# Altium schematic sheet Linefollower_main_board.SchDoc
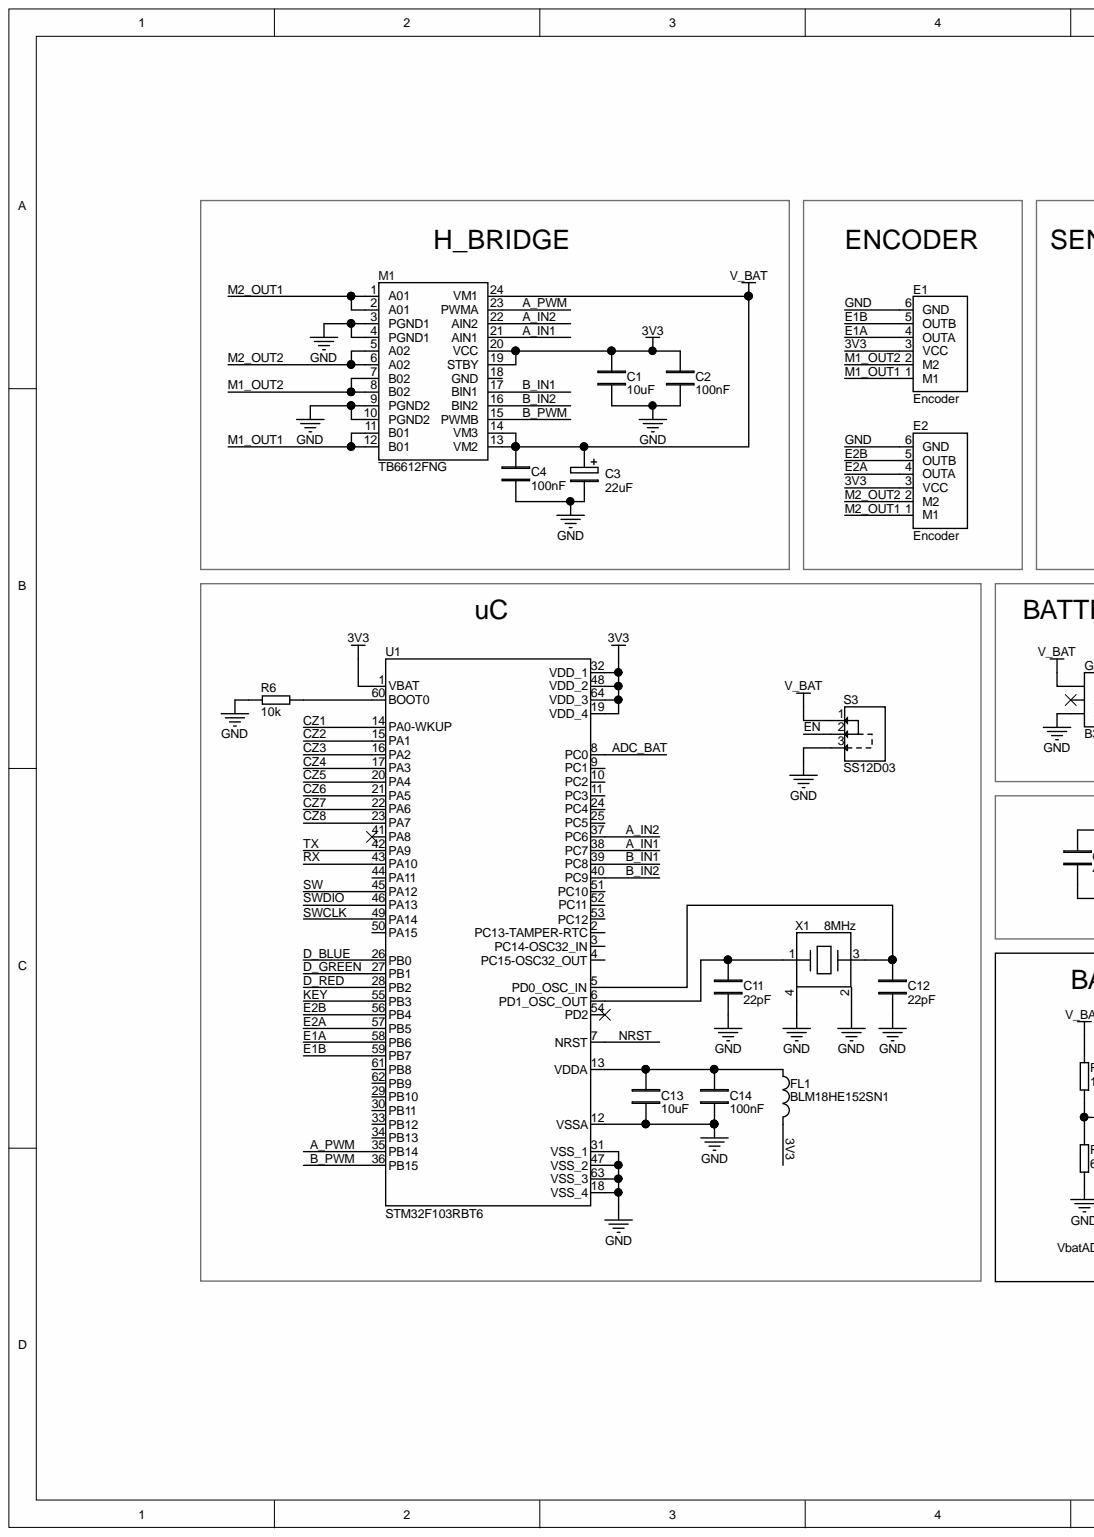
[diagram p0: Linefollower_main_board.SchDoc, left]
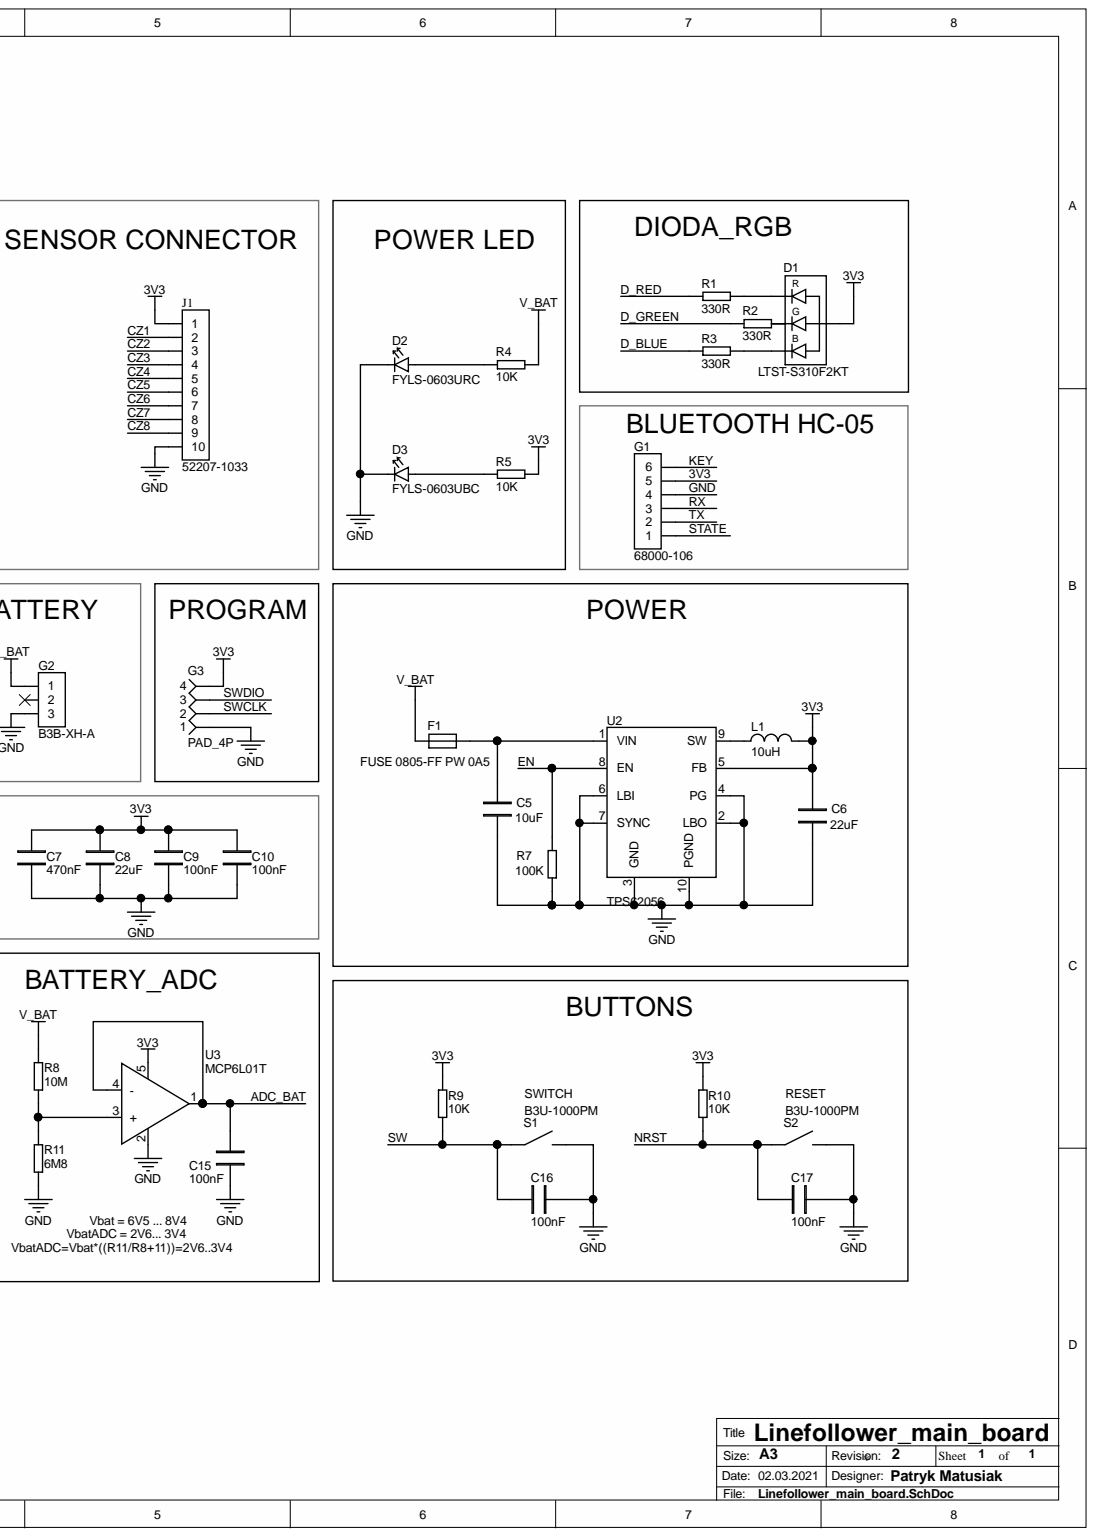
[diagram p1: Linefollower_main_board.SchDoc, right]

## Parts
C1  value 10uF  [CAP0603]  (hidden comment: 10uF  0603)
C2  value 100nF  [CAP0603]  (hidden comment: 100nF  0603)
C3  value 22uF  [SMD_A]  (hidden comment: 22uF  SMD_A)
C4  value 100nF  [CAP0603]  (hidden comment: 100nF  0603)
C5  value 10uF  [CAP1206]  (hidden comment: 10uF  1206)
C6  value 22uF  [CAP1206]  (hidden comment: 22uF  1206)
C7  value 470nF  [CAP0603]  (hidden comment: 470nF  0603)
C8  value 22uF  [CAP1206]  (hidden comment: 22uF  1206)
C9  value 100nF  [CAP0603]  (hidden comment: 100nF  0603)
C10  value 100nF  [CAP0603]  (hidden comment: 100nF  0603)
C11  value 22pF  [CAP0402]  (hidden comment: 22pF  0402)
C12  value 22pF  [CAP0402]  (hidden comment: 22pF  0402)
C13  value 10uF  [CAP0603]  (hidden comment: 10uF  0603)
C14  value 100nF  [CAP0603]  (hidden comment: 100nF  0603)
C15  value 100nF  [CAP0603]  (hidden comment: 100nF  0603)
C16  value 100nF  [CAP0603]  (hidden comment: 100nF  0603)
C17  value 100nF  [CAP0603]  (hidden comment: 100nF  0603)
D1  Part_number: LTST-S310F2KT  [SMD_4_Diode_RGB_LTST]  (hidden comment: SMD-4    LTST-S310F2KT)
D2  Part_number: FYLS-0603URC  [DIODE0603_RED]  (hidden comment: 0603  FYLS-0603URC)
D3  Part_number: FYLS-0603UBC  [DIODE0603_BLUE]  (hidden comment: 0603  FYLS-0603UBC)
E1  Individual  Encoder  [Magnetic Encoder with Motor]  (value not drawn)
E2  Individual  Encoder  [Magnetic Encoder with Motor]  (value not drawn)
F1  500mA  0805  FUSE 0805-FF PW 0A5  [FUSE0805]  (value not drawn)
FL1  Part_number: BLM18HE152SN1  [Inductor0603]  (hidden comment: 0603  BLM18HE152SN1)
G1  Part_number: 68000-106  [GOLDPIN_6P_2.54MM]  (hidden comment: THT  68000-106)
G2  B3B-XH-A  [B3B_XH_A]
G3  PAD_4P  [PAD_4P_1.27mm]
J1  Part_number: 52207-1033  [MOLEX FFC 1mm 10 PIN]  (hidden comment: PIN10, SMD, 1mm  52207-1033)
L1  value 10uH  [7.3MMX7.3MMX4.5MM]  (hidden comment: 10uH  7.3x7.3x3.5mm  SRR0735A-100M)
M1  SSOP24  TB6612FNG  [SSOP24]  (value not drawn)
R1  value 330R  [Res0603]  (hidden comment: 330R  0603)
R2  value 330R  [Res0603]  (hidden comment: 330R  0603)
R3  value 330R  [Res0603]  (hidden comment: 330R  0603)
R4  value 10K  [Res0603]  (hidden comment: 10K  0603)
R5  value 10K  [Res0603]  (hidden comment: 10K  0603)
R6  value 10k  [Res0603]  (hidden comment: 10k  0603)
R7  value 100K  [Res0603]  (hidden comment: 100K  0603)
R8  value 10M  [Res0603]  (hidden comment: 10M  0603)
R9  value 10K  [Res0603]  (hidden comment: 10K  0603)
R10  value 10K  [Res0603]  (hidden comment: 10K  0603)
R11  value 6M8  [Res0603]  (hidden comment: 6M8  0603)
S1  B3U-1000PM  [B3U-1000PM]
S2  B3U-1000PM  [B3U-1000PM]
S3  SS12D03  [SS12D03]
U1  LQFP (64) 10MMX10MM  STM32F103RBT6  [LQFP (64)  10MMx10MM]  (value not drawn)
U2  MSOP-10  TPS62056  [MSOP-10]  (value not drawn)
U3  SC-70-5  MCP6L01T  [SC-70-5]  (value not drawn)
X1  value 8MHz  [SMD 5mm x 3.2mm]  (hidden comment: 8MHz  SMD, 5mm x 3.2mm  ABM3B-8.000MHZ-B2-T)

## Nets
V_BAT: C3.1 C4.2 F1.1 G2.1 M1.13(VM2) M1.14(VM3) M1.24(VM1) R4.2 R8.2 S3.1
3V3: C1.2 C2.2 C6.2 C7.1 C8.1 C9.1 C10.1 D1.4 E1.3(VCC) E2.3(VCC) FL1.2 G1.5 G3.4 J1.1 L1.2 M1.19(STBY) M1.20(VCC) R5.2 R9.1 R10.1 U1.1(VBAT) U1.19(VDD_4) U1.32(VDD_1) U1.48(VDD_2) U1.64(VDD_3) U2.5(FB) U3.5
A_IN1: M1.21(AIN1) U1.38(PC7)
A_IN2: M1.22(AIN2) U1.37(PC6)
A_PWM: M1.23(PWMA) U1.35(PB14)
ADC_BAT: C15.2 U1.8(PC0) U3.1 U3.4(-)
B_IN1: M1.17(BIN1) U1.39(PC8)
B_IN2: M1.16(BIN2) U1.40(PC9)
B_PWM: M1.15(PWMB) U1.36(PB15)
CZ1: J1.2 U1.14(PA0-WKUP)
CZ2: J1.3 U1.15(PA1)
CZ3: J1.4 U1.16(PA2)
CZ4: J1.5 U1.17(PA3)
CZ5: J1.6 U1.20(PA4)
CZ6: J1.7 U1.21(PA5)
CZ7: J1.8 U1.22(PA6)
CZ8: J1.9 U1.23(PA7)
D_BLUE: R3.1 U1.26(PB0)
D_GREEN: R2.1 U1.27(PB1)
D_RED: R1.1 U1.28(PB2)
E1A: E1.4(OUTA) U1.58(PB6)
E1B: E1.5(OUTB) U1.59(PB7)
E2A: E2.4(OUTA) U1.57(PB5)
E2B: E2.5(OUTB) U1.56(PB4)
EN: R7.2 S3.2 U2.8(EN)
GND: C1.1 C2.1 C3.2 C4.1 C5.1 C6.1 C7.2 C8.2 C9.2 C10.2 C11.1 C12.1 C13.1 C14.1 C15.1 C16.2 C17.2 D2.1 D3.1 E1.6(GND) E2.6(GND) G1.4 G2.3 G3.1 J1.10 M1.3(PGND1) M1.4(PGND1) M1.9(PGND2) M1.10(PGND2) R6.1 R7.1 R11.1 S1.1 S2.1 S3.3 U1.12(VSSA) U1.18(VSS_4) U1.31(VSS_1) U1.47(VSS_2) U1.63(VSS_3) U2.2(LBO) U2.3(GND) U2.4(PG) U2.6(LBI) U2.7(SYNC) U2.10(PGND) U3.2 X1.2 X1.4
KEY: G1.6 U1.55(PB3)
M1_OUT1: E1.1(M1) M1.11(B01) M1.12(B01)
M1_OUT2: E1.2(M2) M1.7(B02) M1.8(B02)
M2_OUT1: E2.1(M1) M1.1(A01) M1.2(A01)
M2_OUT2: E2.2(M2) M1.5(A02) M1.6(A02)
NRST: C17.1 R10.2 S2.2 U1.7(NRST)
RX: G1.3 U1.43(PA10)
STATE: G1.1
SW: C16.1 R9.2 S1.2 U1.45(PA12)
SWCLK: G3.2 U1.49(PA14)
SWDIO: G3.3 U1.46(PA13)
TX: G1.2 U1.42(PA9)
NetC5_2: C5.2 F1.2 U2.1(VIN)
NetC11_2: C11.2 U1.6(PD1_OSC_OUT) X1.1
NetC12_2: C12.2 U1.5(PD0_OSC_IN) X1.3
NetC13_2: C13.2 C14.2 FL1.1 U1.13(VDDA)
NetD1_1: D1.1 R1.2
NetD1_2: D1.2 R2.2
NetD1_3: D1.3 R3.2
NetD2_2: D2.2 R4.1
NetD3_2: D3.2 R5.1
NetL1_1: L1.1 U2.9(SW)
NetR6_2: R6.2 U1.60(BOOT0)
NetR8_1: R8.1 R11.2 U3.3(+)

Not connected: G2 2; M1 18(GND); U1 2(PC13-TAMPER-RTC) 3(PC14-OSC32_IN) 4(PC15-OSC32_OUT) 9(PC1) 10(PC2) 11(PC3) 24(PC4) 25(PC5) 29(PB10) 30(PB11) 33(PB12) 34(PB13) 41(PA8) 44(PA11) 50(PA15) 51(PC10) 52(PC11) 53(PC12) 54(PD2) 61(PB8) 62(PB9)

## Library data of the parts
C1 C2 C4 C7-C14 = Capacitor: Component Kind: Standard; Description: Capacitor; Library Name: Library.IntLib
  C1 C2 C4 C7 C9 C10 C13 C14: Package: 0603
  C8: Package: 1206
  C11 C12: Package: 0402
C3 = Capacitor_Polarity: Component Kind: Standard; Description: Capacitor_Polarity; Library Name: Library.IntLib; Package: SMD_A
C5 C6 = Capacitor: Tolerance: +/-10%; Voltage: 10V; Component Kind: Standard; Description: Capacitor; Library Name: Library.IntLib; Package: 1206
C15 = Capacitor: Tolerance: 5%; Voltage: 16V; Component Kind: Standard; Description: Capacitor; Library Name: Library.IntLib; Package: 0603
C16 C17 = Capacitor: Tolerance: +/-10%; Voltage: 16V; Component Kind: Standard; Description: Capacitor; Library Name: Library.IntLib; Package: 0603
D1 = Diode_LTST-S310F2KT: Diode RGB, SMD LED Side Look Red/Green/Blue; Component Kind: Standard; Library Name: Library.IntLib; Value: SMD-4
D2 = DiodeLED_0603_red: LED; SMD; 0603; red 80mcd; 1,6x0,8x0,8mm; 130°; 2,1÷2.6V; Manufacturer: Foryard; Component Kind: Standard; Library Name: Diode.SchLib; Package: 0603
D3 = DiodeLED_0603_blue: LED; SMD; 0603; blue 120mcd; 1,6x0,8x0,8mm; 130°; 2,8÷3,6V; Manufacturer: Foryard; Component Kind: Standard; Library Name: Diode.SchLib; Package: 0603
E1 E2 = Encoder: Magnetic Encoder Pair Kit for Micro Metal Gearmotors, 12 CPR, 2.7-18V; Manufacturer: Pololu; Component Kind: Standard; Library Name: Device.SchLib; Package: Individual; Part_number: Encoder
F1 = FUSE 0805-FF PW 0A5: FUSE, 500mA, 32V, 0805; Component Kind: Standard; Library Name: Library.IntLib; Package: 0805; Part_number: FUSE 0805-FF PW 0A5; Value: 500mA
FL1 = Inductor: Ferrite Beads; Component Kind: Standard; Library Name: Library.IntLib; Package: 0603
G1 = GOLDPIN_6P: Goldpin 2,54mm 6PIN; Component Kind: Standard; Library Name: Library.IntLib; Package: THT
G2 = B3B_XH_A: JST 2,5mm, XH, 3PIN, THT, 250V, 3A; Manufacturer: JST Japanese Solderless Terminals; Component Kind: Standard; Library Name: Library.IntLib; Part_number: B3B-XH-A
G3 = PAD_4P: PAD Hole 0.6mm Radius 0.5mm; Component Kind: Standard; Library Name: Library.IntLib; Part_number: PAD_4P
J1 = Molex FFC 1mm 10 PIN: Connector Molex FFC 1mm 10 PIN; Component Kind: Standard; Library Name: Connector.SchLib; Manufacturer: Molex; Package: PIN10, SMD, 1mm
L1 = Inductor 10uH 2.1A: Inductor 10uH 20% 2.1A SMD 0735 AEC-Q200; Component Kind: Standard; Current: 2.1A; Library Name: Library.IntLib; Package: 7.3x7.3x3.5mm; Part_number: SRR0735A-100M
M1 = TB6612FNG: Driver IC for Dual DC motor; Manufacturer: Toshiba; Component Kind: Standard; Library Name: H_bridge.SchLib; Package: SSOP24; Part_number: TB6612FNG
R1-R7 R9 R10 = Resistor: Component Kind: Standard; Description: Resistor; Package: 0603
  R1-R3 R7 R9 R10: Library Name: Resistor.SchLib
  R4-R6: Library Name: Library.IntLib
R8 R11 = Resistor: Tolerance: 1%; Component Kind: Standard; Description: Resistor; Library Name: Resistor.SchLib; Package: 0603
S1 S2 = Switch SMD B3U-1000PM: TACT; SPST-NO; Poz: 2; 0,05A/24VDC; SMT; 1,5N; Component Kind: Standard; Library Name: Library.IntLib; Part_number: B3U-1000PM
S3 = SS12D03: Slide switch; Poz: 2; 0,5A/60VDC; THT; Manufacturer: SHENZHEN XIEJIA ELECTRONICS; Component Kind: Standard; Library Name: Switch.SchLib; Part_number: SS12D03
U1 = STM32F103RBT6: Medium-density performance line ARM-based 32-bit MCU with 64 or128 KB Flash, USB, CAN, 7 timers, 2 ADCs, 9 communication interfaces; Manufacturer: STMicroelectronics; Component Kind: Standard; Library Name: Microcontroller.SchLib; Package: LQFP (64) 10MMX10MM; Part_number: STM32F103RBT6
U2 = TPS62056: Synchronous Step-Down Converter, 800mA, Vout=3.3V; Manufacturer: Texas Instruments; Component Kind: Standard; Library Name: Voltage_Converter.SchLib; Package: MSOP-10; Part_number: TPS62056
U3 = MCP6L01T: MCP6L01, Operational Amplifier, 1MHz, 85uA; Manufacturer: Microchip; Component Kind: Standard; Library Name: Operational_Amplifier.SchLib; Package: SC-70-5; Part_number: MCP6L01T
X1 = Crystal MHz ABM3B-8: Crystals 8MHz; ±50ppm; 18pF; SMD; 5x3,2x1,1mm; Manufacturer: Abracon; Component Kind: Standard; Library Name: Library.IntLib; Package: SMD, 5mm x 3.2mm; Part_number: ABM3B-8.000MHZ-B2-T
